# Altium schematic sheet Codec.SchDoc
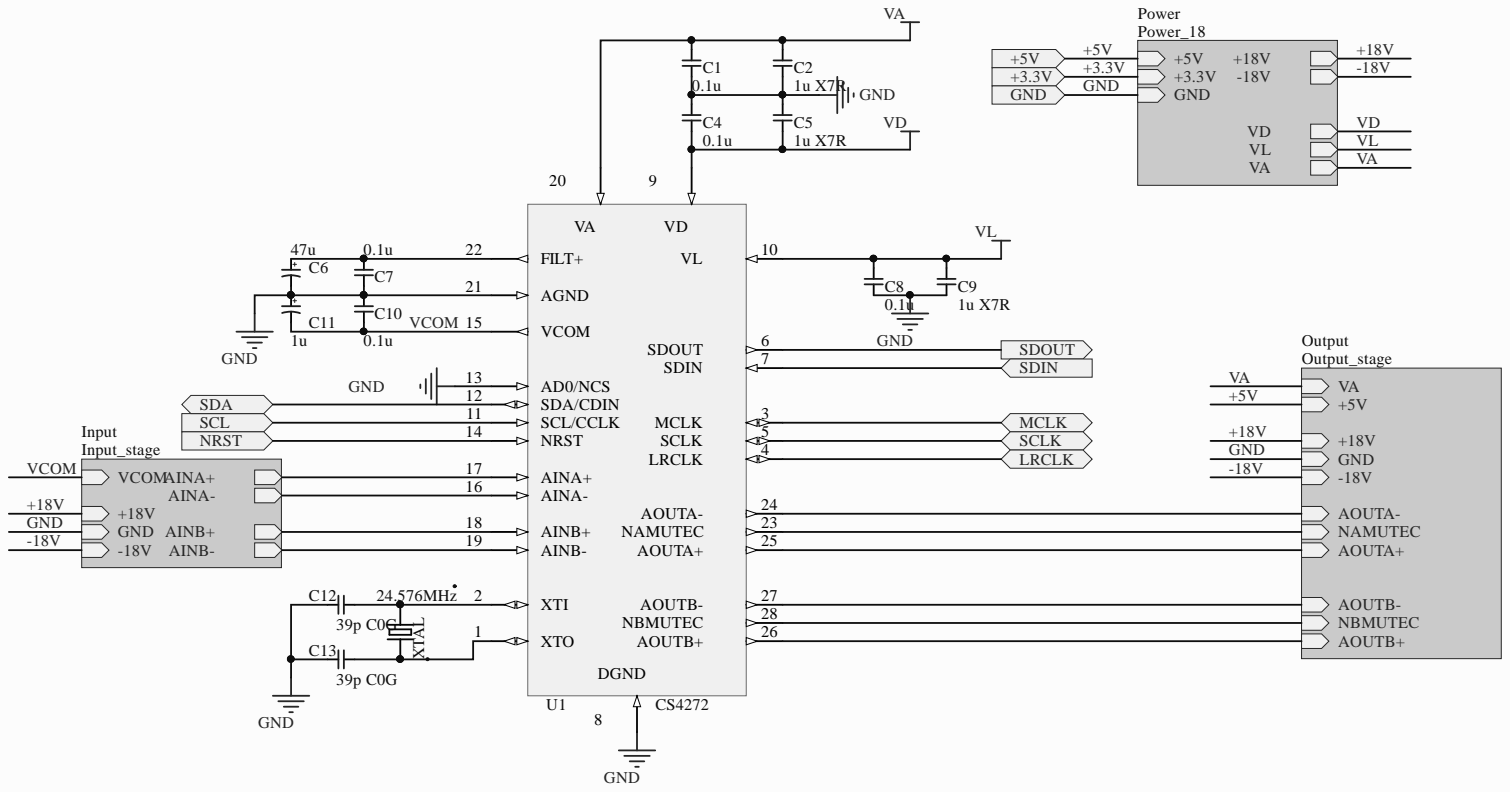
[diagram p0: Codec.SchDoc]

## Parts
24.576MHz  XTAL  [XTAL_hc-49_smd]
C1  0.1u  [0805_cap]
C2  1u X7R  [0805_cap]
C4  0.1u  [0805_cap]
C5  1u X7R  [0805_cap]
C6  47u  [cap 4x5.4]
C7  0.1u  [0805_cap]
C8  0.1u  [0805_cap]
C9  1u X7R  [0805_cap]
C10  0.1u  [0805_cap]
C11  1u  [cap 4x5.4]
C12  39p C0G  [0805_cap]
C13  39p C0G  [0805_cap]
U1  CS4272  [TSSOP-28]

## Ports
+3.3V, +5V, GND, LRCLK, MCLK, NRST, SCL, SCLK, SDA, SDIN, SDOUT

## Nets
GND: C1.1 C2.1 C4.2 C5.2 C6.2 C7.1 C8.1 C9.1 C10.2 C11.1 C12.1 C13.1 U1.8 U1.13(AD0/NCS) U1.21(AGND) ; port GND
LRCLK: U1.4 ; port LRCLK
MCLK: U1.3 ; port MCLK
NRST: U1.14(NRST) ; port NRST
SCL: U1.11(SCL/CCLK) ; port SCL
SCLK: U1.5 ; port SCLK
SDA: U1.12(SDA/CDIN) ; port SDA
SDIN: U1.7 ; port SDIN
SDOUT: U1.6 ; port SDOUT
VA: C1.2 C2.2 U1.20
VCOM: C10.1 C11.2 U1.15(VCOM)
VD: C4.1 C5.1 U1.9
VL: C8.2 C9.2 U1.10
Net24.576MHz_1: 24.576MHz.1 C13.2 U1.1(XTO)
Net24.576MHz_2: 24.576MHz.2 C12.2 U1.2(XTI)
NetC6_1: C6.1 C7.2 U1.22(FILT+)
+3.3V: ; port +3.3V
+5V: ; port +5V
+18V:
-18V:

Not connected: U1 16(AINA-) 17(AINA+) 18(AINB+) 19(AINB-) 23 24 25 26 27 28

## Library data of the parts
24.576MHz = hc-49_smd: Crystal 4MHz ?30ppm (Tol) ?50ppm (Stability) 20pF FUND 200Ohm 2-Pin HC-49/SDLF SMD T/R; MF: Fox Electronics; MP: FOXSDLF/040; Availability: Good; Component Kind: Standard; Package: HC49SD Fox Electronics; Price: 0.34 USD; SnapEDA_Link: https://www.snapeda.com/symbols-footprints/FOXSDLF/040/Fox%20Electronics/view-part/?ref=snap; Library Name: Codec_board.SchLib
C1 C2 C4 C5 C7-C10 C12 C13 = Cap: Component Kind: Standard; Library Name: Codec_board.SchLib
C6 C11 = Cap_electrolytic: Component Kind: Standard; Library Name: Codec_board.SchLib
U1 = CS4272: Component Kind: Standard; Library Name: Codec_board.SchLib
